# Altium schematic sheet imbuelight_move_detection.SchDoc
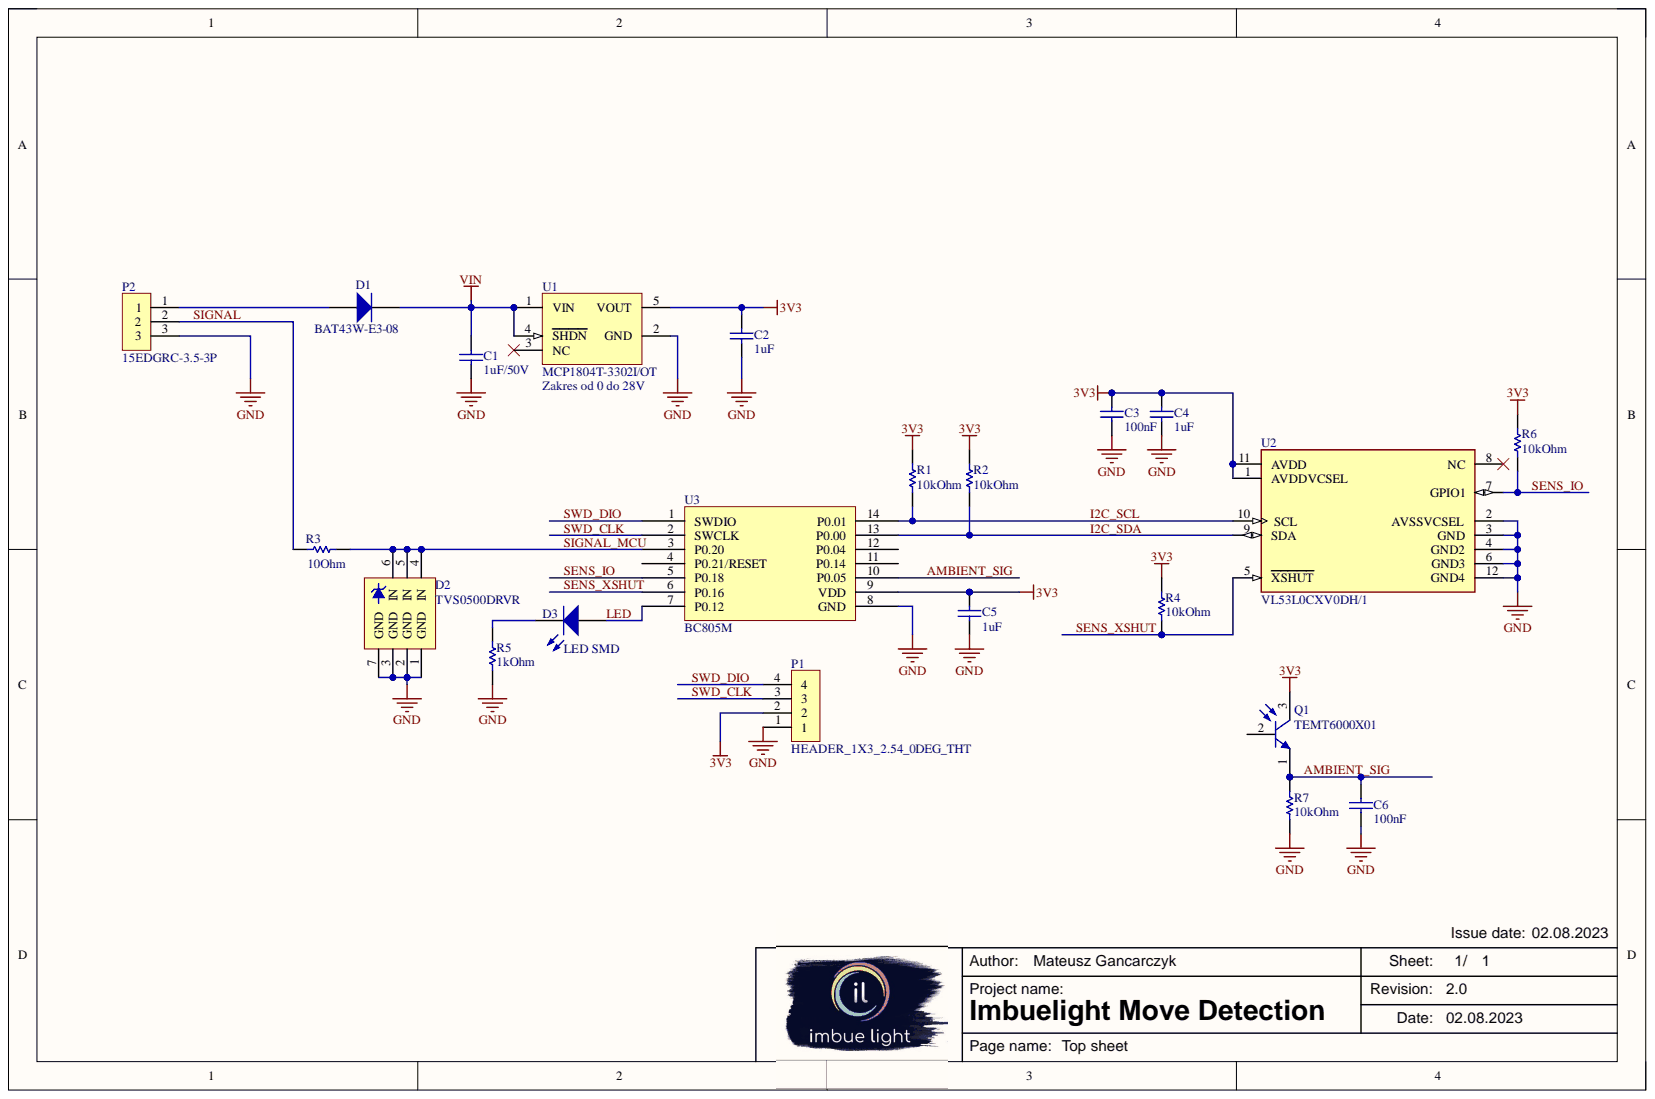
[diagram p0: imbuelight_move_detection.SchDoc]

## Parts
C1  Wartość: 1uF/50V  [CAP_SMD_0805]  (hidden comment: 0805 1uF 20V)
C2  Wartość: 1uF  [CAP_SMD_0603]  (hidden comment: 0603 1uF 10V)
C3  Wartość: 100nF  [CAP_SMD_0603]  (hidden comment: 0603 1uF 10V)
C4  Wartość: 1uF  [CAP_SMD_0603]  (hidden comment: 0603 1uF 10V)
C5  Wartość: 1uF  [CAP_SMD_0603]  (hidden comment: 0603 1uF 10V)
C6  Wartość: 100nF  [CAP_SMD_0603]  (hidden comment: 0603 1uF 10V)
D1  BAT43W-E3-08  [SOD-123]
D2  TVS0500DRVR  [FP-DRV0006A-IPC_C]
D3  Wartość: LED SMD  [LED SMD 1206]  (hidden comment: Dioda LED SMD 1206)
P1  HEADER_1X3_2.54_0DEG_THT  [HDR1X4]
P2  15EDGRC-3.5-3P  [15EDGVC-3.5-03P-14-00A(H)]
Q1  TEMT6000X01  [VSIL-TEMT6000X01_V]
R1  Resistance: 10kOhm  [RES_0603]  (hidden comment: 0603 10kOhm 1%)
R2  Resistance: 10kOhm  [RES_0603]  (hidden comment: 0603 10kOhm 1%)
R3  Resistance: 10Ohm  [RES_0603]  (hidden comment: 0603 10Ohm 1%)
R4  Resistance: 10kOhm  [RES_0603]  (hidden comment: 0603 10kOhm 1%)
R5  Resistance: 1kOhm  [RES_0603]  (hidden comment: 0603 1kOhm 1%)
R6  Resistance: 10kOhm  [RES_0603]  (hidden comment: 0603 10kOhm 1%)
R7  Resistance: 10kOhm  [RES_0603]  (hidden comment: 0603 10kOhm 1%)
U1  MCP1804T-3302I/OT  [SOT-23-5]
U2  VL53L0CXV0DH/1  [FP-LGA-12-MFG]
U3  BC805M  [BC805]

## Nets
3V3: C2.2 C3.2 C4.2 C5.2 P1.2 Q1.3 R1.1 R2.1 R4.2 R6.1 U1.5(VOUT) U2.1(AVDDVCSEL) U2.11(AVDD) U3.9(VDD)
GND: C1.1 C2.1 C3.1 C4.1 C5.1 C6.1 D2.1(GND) D2.2(GND) D2.3(GND) D2.7(GND) P1.1 P2.3 R5.1 R7.2 U1.2(GND) U2.2(AVSSVCSEL) U2.3(GND) U2.4(GND2) U2.6(GND3) U2.12(GND4) U3.8(GND)
VIN: C1.2 D1.K U1.1(VIN) U1.4(SHDN)
AMBIENT_SIG: C6.2 Q1.1 R7.1 U3.10(P0.05)
I2C_SCL: R1.2 U2.10(SCL) U3.14(P0.01)
I2C_SDA: R2.2 U2.9(SDA) U3.13(P0.00)
LED: D3.A U3.7(P0.12)
SENS_IO: R6.2 U2.7(GPIO1) U3.5(P0.18)
SENS_XSHUT: R4.1 U2.5(XSHUT) U3.6(P0.16)
SIGNAL: P2.2 R3.1
SIGNAL_MCU: D2.4(IN) D2.5(IN) D2.6(IN) R3.2 U3.3(P0.20)
SWD_CLK: P1.3 U3.2(SWCLK)
SWD_DIO: P1.4 U3.1(SWDIO)
NetD1_A: D1.A P2.1
NetD3_K: D3.K R5.2

Not connected: Q1 2; U1 3(NC); U2 8(NC); U3 4(P0.21/RESET) 11(P0.14) 12(P0.04)

## Library data of the parts
C1-C6 = Capacitor SMD: Capacitor; Component Kind: Standard; Library Name: MY_LIB.IntLib
D1 = Dioda SMD: Component Kind: Standard; Description: Dioda SMD; Library Name: MY_LIB.SchLib; Wartość: BAT43W-E3-08
D2 = TVS0500DRVR: ESD Suppressors / TVS Diodes 5V flat-clamp surge protection device 6-WSON -40 to 125; Component Kind: Standard; Library Name: MY_LIB.SchLib; Supplier 1: Digi-Key; Supplier Part Number 1: 296-48382-1-ND
P1 = Header 1x4: 4pin 1x4 2.54mm 0.100" pitch header vertical tht; Component Kind: Standard; Library Name: MY_LIB.SchLib; Manufacturer Part Number: HEADER_1X3_2.54_0DEG_THT
P2 = 15EDGVC-3.5-03P-14-00A(H): 15EDGVC-3.5-03P-14-00A(H) - 3 pin; Component Kind: Standard; Library Name: MY_LIB.SchLib; Manufacturer Part Number: 15EDGRC-3.5-3P
Q1 = CMP-1666-00035-1: Ambient Light Sensor  , 100 mW, -40 to 100 degC, 3-Pin SMD, RoHS, Tape and Reel; Case\Package: 0603; Colour: Red; Forward Current: 20 mA; Forward Voltage: 2 V; Lens Style: Diffused; Luminous Intensity: 54 mcd; Power Rating: 75 mW; Viewing Angle: 130 degrees; Case Code (Imperial): 0603; Case Code (Metric): 1608; Component Kind: Standard; ComponentLink1Description: Manufacturer URL; ComponentLink1URL: http://www.vishay.com/; ComponentLink2Description: Datasheet; ComponentLink2URL: http://www.vishay.com/docs/81579/temt6000.pdf; DatasheetVersion: Rev. 1.9, 08/2011; Height: 0.8 mm; Illumination Colour: Red; Length: 1.6 mm; Library Name: Altium Content Vault; Max Operating Temperature: 85 degC; Min Operating Temperature: -55 degC; Mount: Surface Mount; Nominal Vf: 2 V; Package Quantity: 3000; PackageDescription: 3-Pin Surface Mount Device, Body 4 x 2 mm; PackageReference: 1206; Packaging: Tape and Reel; Pins: 2; Radiation Hardening: No; RoHSCompliant: true; Width: 0.8 mm
R1-R7 = Resistor SMD_1: Generic Resistor SMD; Component Kind: Standard; Library Name: MY_LIB.IntLib; Supplier 1: LCSC
  R1 R2 R4 R6 R7: Manufacturer Part Number: 0603 10kOhm 1%; Supplier Part Number 1: C3017715
  R3: Manufacturer Part Number: 0603 10Ohm 1%; Supplier Part Number 1: C22859
  R5: Manufacturer Part Number: 0603 1kOhm 1%; Supplier Part Number 1: C3016283
U1 = MCP1804T-3302I/OT: Regulatro LDO 3,3V; Manufacturer: Microchip; Code_IPC: SOT23-5; Component Kind: Standard; ComponentLink1Description: Manufacturer URL; ComponentLink1URL: http://www.microchip.com/; ComponentLink2Description: Datasheet; ComponentLink2URL: http://ww1.microchip.com/downloads/en/DeviceDoc/22200b.pdf; ComponentLink3Description: Package Specification; ComponentLink3URL: http://www.microchip.com/stellent/groups/techpub_sg/documents/packagingspec/en012702.pdf; DatasheetVersion: revB, Nov-2009; Library Name: MY_LIB.SchLib; Mounting Technology: Surface Mount; PackageDescription: 5-Lead Plastic Small Outline Transistor (OT) [SOT-23]; PackageReference: SOT-23-OT5; PackageVersion: revBB, Aug-2009; PartNumber: MCP1804T-3302I/OT; Supplier 1: Digi-Key; Supplier 2: Digi-Key; Supplier 3: Digi-Key; Supplier 4: Farnell; Supplier 5: Newark; Supplier 6: Newark; Supplier 7: Mouser; Supplier Part Number 1: MCP1804T-3302I/OTTR-ND; Supplier Part Number 2: MCP1804T-3302I/OTCT-ND; Supplier Part Number 3: MCP1804T-3302I/OTDKR-ND; Supplier Part Number 4: 1825006; Supplier Part Number 5: 55R1047; Supplier Part Number 6: 52R9938; Supplier Part Number 7: 579-MCP1804T-3302IOT
U2 = VL53L0CXV0DH/1: SENSOR OPTICAL 200CM I2C; Manufacturer: STMicroelectronics; Max Supply Voltage: 3.5V; Min Supply Voltage: 1.6V; Operating Supply Current: 0.016-19mA; Automotive: No; Category: IC; Component Kind: Standard; ComponentLink2Description: Datasheet; ComponentLink2URL: https://www.st.com/resource/en/datasheet/vl53l0x.pdf; Device Class L1: Sensors; Device Class L2: Optical Sensors; Device Class L3: unset; Digikey Description: SENSOR OPTICAL 200CM I2C; Height: 1.05mm; Lead Free: Yes; Library Name: MY_LIB.SchLib; Manufacturer Part Number: VL53L0CXV0DH/1; Octopart: https://octopart.com/vl53l0cxv0dh%2F1-stmicroelectronics-71168165; Package: LGA-12; RoHS: TRUE; Sensor Output: I2C; Temperature: -20°C to +70°C; Temperature Range High: +70°C; Temperature Range Low: -20°C
U3 = BC805M: Bluetooth Low Energy (BLE) 5 Module; Component Kind: Standard; Library Name: MY_LIB.SchLib; Supplier 1: Digi-Key; Supplier Part Number 1: 1914-BC805MCT-ND
D3 = Dioda LED SMD 1206: Component Kind: Standard; Description: Dioda LED SMD 1206; Library Name: MY_LIB.IntLib; Opis: Dioda LED; Typ obudowy: SMD 1206
